# Altium schematic sheet Main.SchDoc(Main)
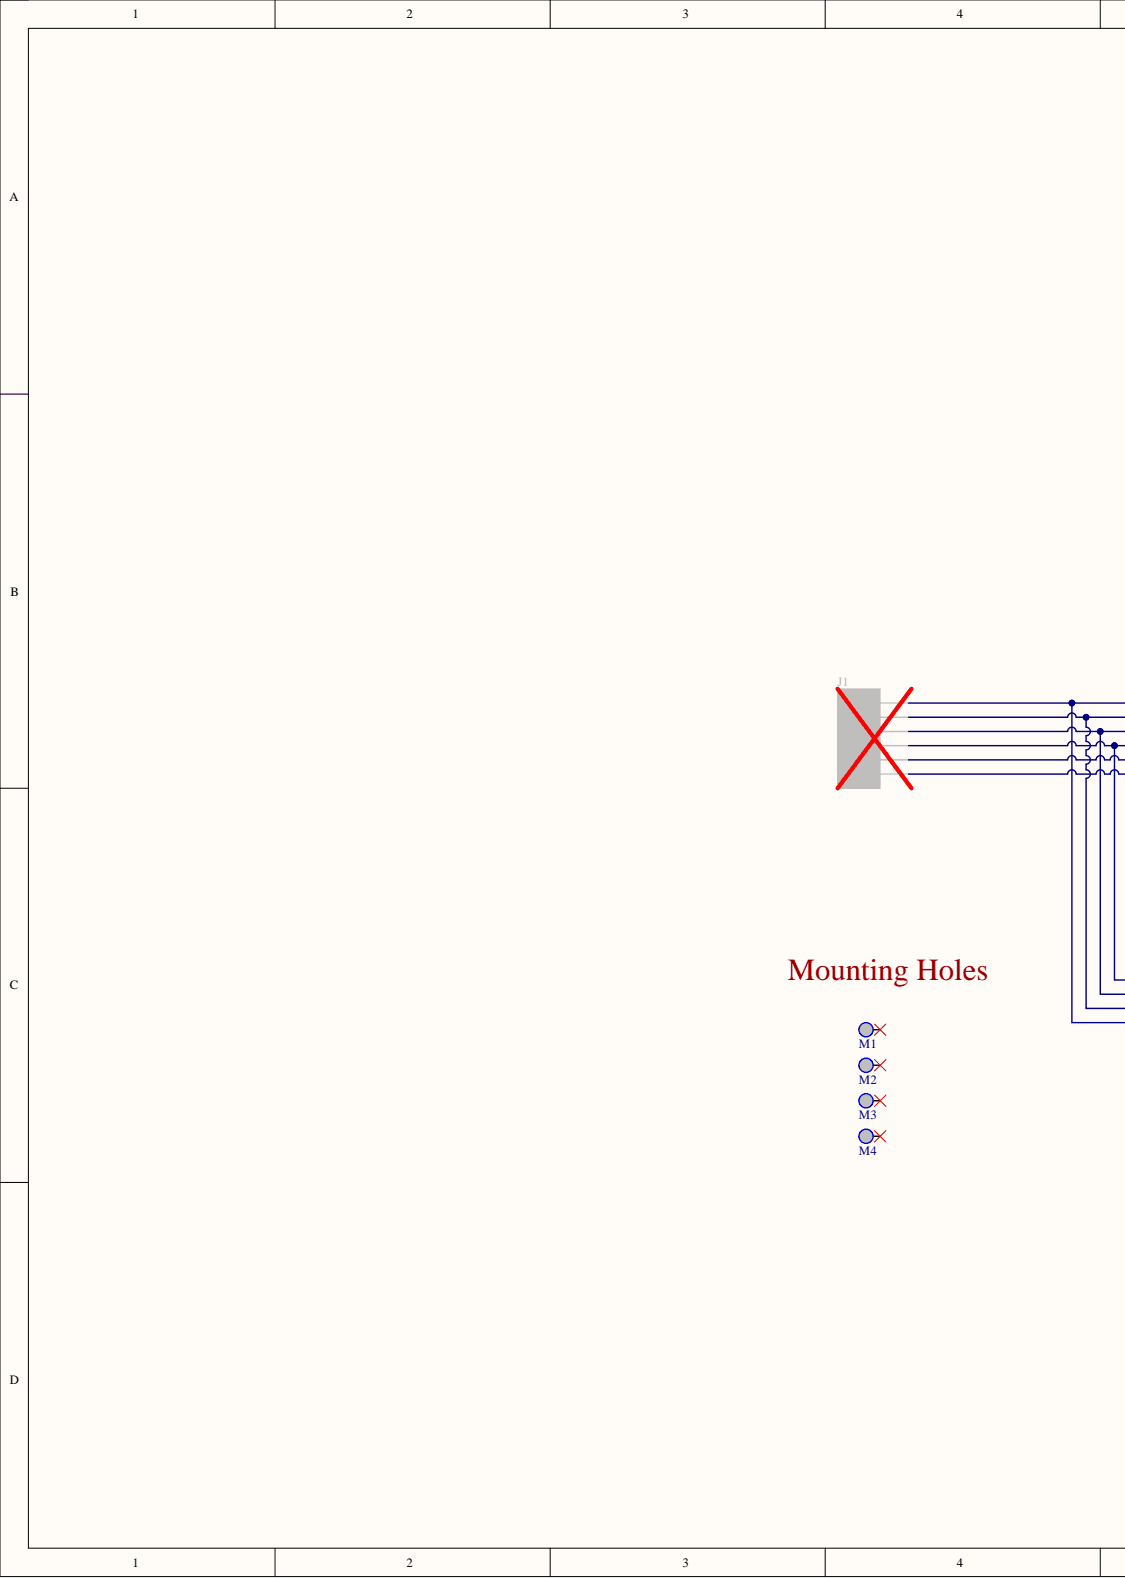
[diagram p0: Main.SchDoc, left]
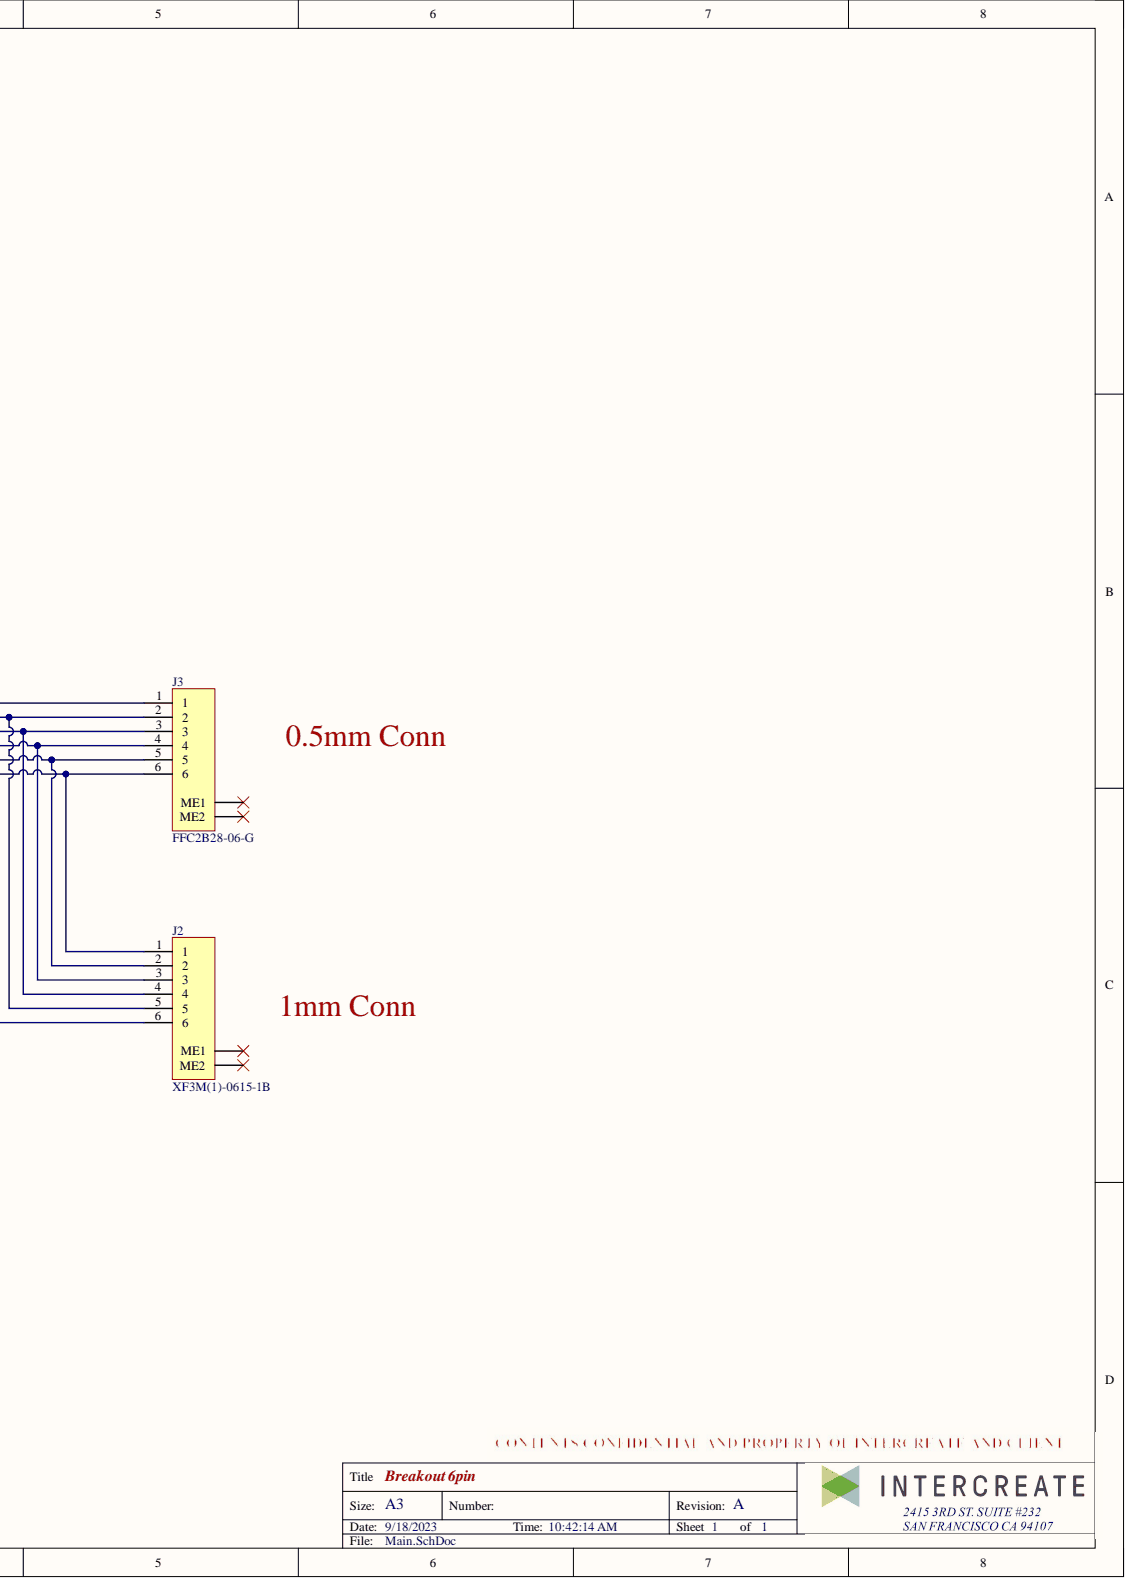
[diagram p1: Main.SchDoc, right]

## Parts
J1  61300611121  [HDR-V-1X6]  (value not drawn)
J2  XF3M(1)-0615-1B  [CONN-FFC-H-6P-1MM]
J3  FFC2B28-06-G  [CONN-FFC-H-6P-0.5MM]
M1  MTG_HOLE  [MH_P_2.5MM]  (value not drawn)  (no BOM)
M2  MTG_HOLE  [MH_P_2.5MM]  (value not drawn)  (no BOM)
M3  MTG_HOLE  [MH_P_2.5MM]  (value not drawn)  (no BOM)
M4  MTG_HOLE  [MH_P_2.5MM]  (value not drawn)  (no BOM)

## Nets
NetJ1_1: J1.1 J2.6 J3.1
NetJ1_2: J1.2 J2.5 J3.2
NetJ1_3: J1.3 J2.4 J3.3
NetJ1_4: J1.4 J2.3 J3.4
NetJ1_5: J1.5 J2.2 J3.5
NetJ1_6: J1.6 J2.1 J3.6

Not connected: J2 ME1 ME2; J3 ME1 ME2; M1 1; M2 1; M3 1; M4 1

## Library data of the parts
J1 = HDR-V-1X6-M: Header, 6-Pin, 0.1"; Manufacturer 1: Wurth Electronics; Connector Type: Male Pin; Current Rating: 3A; Gender: Male; Number of Contacts: 6; Pitch: 0.1inch; Voltage Rating: 250V; Color: Black; Component Kind: Standard; ComponentLink1Description: http://www.mouser.com/ds/2/445/6130xx11121-538006.pdf?src-supplier=Mouser; ComponentLink1URL: http://www.mouser.com/ds/2/445/6130xx11121-538006.pdf?src-supplier=Mouser; ComponentLink2Description: https://katalog.we-online.de/em/datasheet/6130xx11121.pdf?src-supplier=Digi-Key; ComponentLink2URL: https://katalog.we-online.de/em/datasheet/6130xx11121.pdf?src-supplier=Digi-Key; ComponentLink3Description: http://www.farnell.com/cad/1737268.pdf?src-supplier=Element14; ComponentLink3URL: http://www.farnell.com/cad/1737268.pdf?src-supplier=Element14; Contact Gender: Male; Contact Material: Copper Alloy; Contact Mating Length: 0.236inch; Contact Plating: Gold; Housing Material: PBT; Lead Free: Lead Free; Manufacturer Part Number 1: 61300611121; Max Operating Temperature: 125°C; Min Operating Temperature: -40°C; Mount: Through Hole; Number of Positions: 6; Number of Rows: 1; Packaging: Bulk; Pricing 1: 1=0.33000, 10=0.27900, 25=0.23200, 50=0.19400, 100=0.17600, 1000=0.16000 (USD); REACH SVHC: Unknown; RoHS: Yes; Signal Integrity: Connector; Stock 1: 13863; Supplier 1: Digi-Key; Supplier Part Number 1: 732-5319-ND; Termination: Through Hole; Library Name: intercreate.IntLib
J2 = CONN-FFC-H-6P-1MM: 1.0-mm Pitch, Dual Contact ; For FPC/FFC, 6 Contacts, RoHS; Manufacturer 1: Omron; Actuator Color: Black; Actuator Material: Polymer,Liquid Crystal Polymer; Current Rating: 500mA; Mating Cycles: 20; Number of Positions: 6; Pitch: 0.039inch; Voltage Rating: 50V; Category: FFC / FPC; Component Kind: Standard; ComponentLink1Description: https://datasheet.ciiva.com/48563/en-xf3m-48563813.pdf?src-supplier=Avnet; ComponentLink1URL: https://datasheet.ciiva.com/48563/en-xf3m-48563813.pdf?src-supplier=Avnet; Contact Material: Copper Alloy; Contact Plating: Gold; Flammability Rating: UL94 V-0; Housing Color: Natural; Housing Material: Polymer; Manufacturer Part Number 1: XF3M(1)-0615-1B; Max Operating Temperature: 85°C; Min Operating Temperature: -30°C; Mounting Technology: Surface Mount; Number of Rows: 1; Orientation: Right Angle; Package Quantity: 1500; Packaging: Tape and Reel; Pricing: 1=1.22, 10=1.05, 25=0.991, 50=0.958, 100=0.945, 250=0.915, 500=0.86, 1000=0.765 (USD); RoHS: Yes; RoHS Compliant: Yes; Series: XF3M; Stock: 212; Supplier: Newark; Supplier Part Number: 46Y1126; Termination: Solder; Library Name: intercreate.IntLib
J3 = CONN-FFC-H-6P-0.5MM: 6 Position FFC, FPC Connector Contacts, Top and Bottom 0.020" (0.50mm) Surface Mount, Right Angle; Manufacturer 1: Global Connector Technology; Number of Positions: 6; Pitch: 0.5mm; Component Kind: Standard; Manufacturer Part Number 1: FFC2B28-06-G; Library Name: intercreate.IntLib
M1-M4 = MTG_HOLE: MTG HOLE PLATED VARIOUS SIZES; Library Name: intercreate.IntLib
